# Altium schematic sheet Connectors.SchDoc
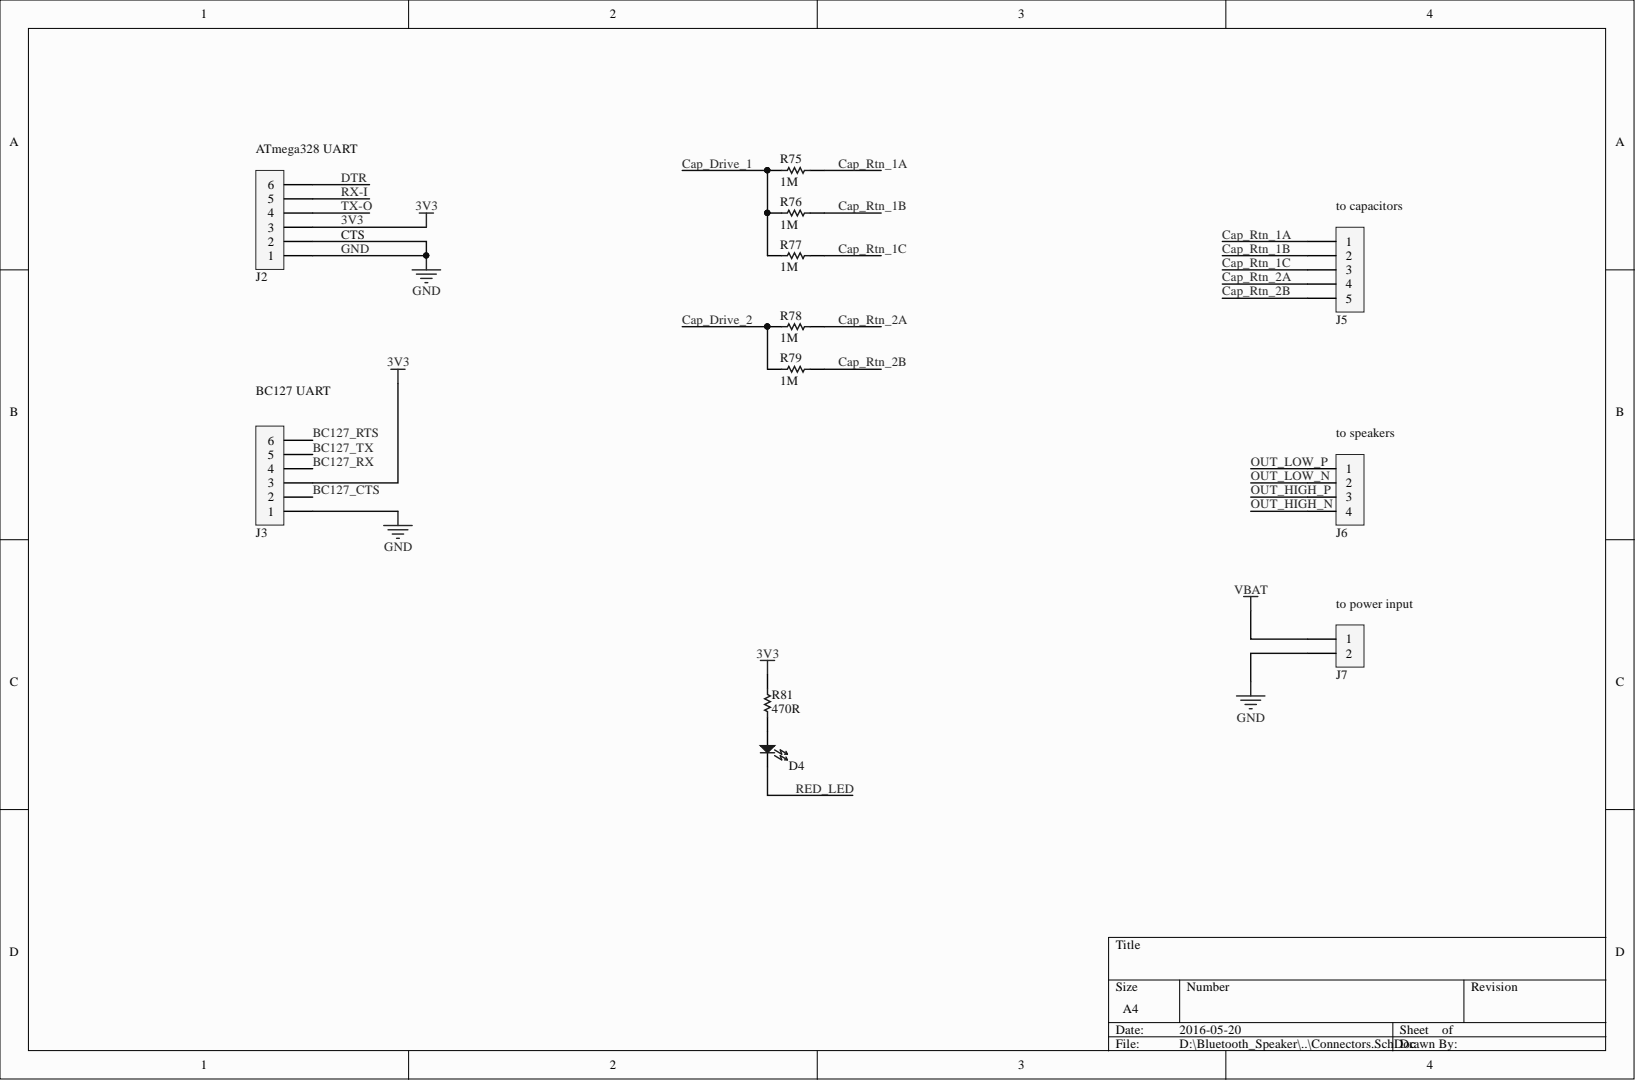
[diagram p0: Connectors.SchDoc]

## Parts
D4  LED 0603  [0603]  (value not drawn)
J2  6POS THRU HOLE 2.54MM  [90147-1106]  (value not drawn)
J3  6POS THRU HOLE 2.54MM  [90147-1106]  (value not drawn)
J5  5POS THRU HOLE 2.54MM  [70543-0004]  (value not drawn)
J6  TERMINAL BLOCK 4POS  [TERMINAL BLOCK 5MM 4POS]  (value not drawn)
J7  TERMINAL BLOCK 2POS  [TERMINAL BLOCK 5MM 2POS]  (value not drawn)
R75  1M  [0603]
R76  1M  [0603]
R77  1M  [0603]
R78  1M  [0603]
R79  1M  [0603]
R81  470R  [0603]

## Nets
VBAT: J7.1
3V3: J2.3 J3.3 R81.2
BC127_CTS: J3.2
BC127_RTS: J3.6
BC127_RX: J3.4
BC127_TX: J3.5
CAP_DRIVE_1: R75.1 R76.1 R77.1
CAP_DRIVE_2: R78.1 R79.1
CAP_RTN_1A: J5.1 R75.2
CAP_RTN_1B: J5.2 R76.2
CAP_RTN_1C: J5.3 R77.2
CAP_RTN_2A: J5.4 R78.2
CAP_RTN_2B: J5.5 R79.2
DTR: J2.6
GND: J2.1 J2.2 J3.1 J7.2
OUT_HIGH_N: J6.4
OUT_HIGH_P: J6.3
OUT_LOW_N: J6.2
OUT_LOW_P: J6.1
RED_LED: D4.1
RX-I: J2.5
TX-O: J2.4
NetD4_2: D4.2 R81.1

## Library data of the parts
D4 = LED 0603: Configuration: Single; Current: 20mA; Voltage: 2.2V; Component Kind: Standard; Temperature: 85dC; Library Name: Speaker Library.SchLib
R75-R79 = RES 1M 0603: Power: 0.1W; Tolerance: 1%; Component Kind: Standard; Digikey Part Number: 311-1.00MHRCT-ND; Value: 1M; Library Name: Speaker Library.SchLib
R81 = RES 470R 0603: Power: 0.25W; Tolerance: 1%; Component Kind: Standard; Type: RES; Value: 470R; Library Name: Speaker Library.SchLib
J2 J3 = 6POS THRU HOLE 2.54MM: Component Kind: Standard; Library Name: Speaker Library.SchLib
J5 = 5POS THRU HOLE 2.54MM: Component Kind: Standard; Library Name: Speaker Library.SchLib
J6 = TERMINAL BLOCK 4POS: Component Kind: Standard; Digikey Part Number: ED2563-ND; Library Name: Speaker Library.SchLib
J7 = TERMINAL BLOCK 2POS: Component Kind: Standard; Digikey Part Number: ED2561-ND; Library Name: Speaker Library.SchLib
